# Altium schematic sheet gps.SchDoc
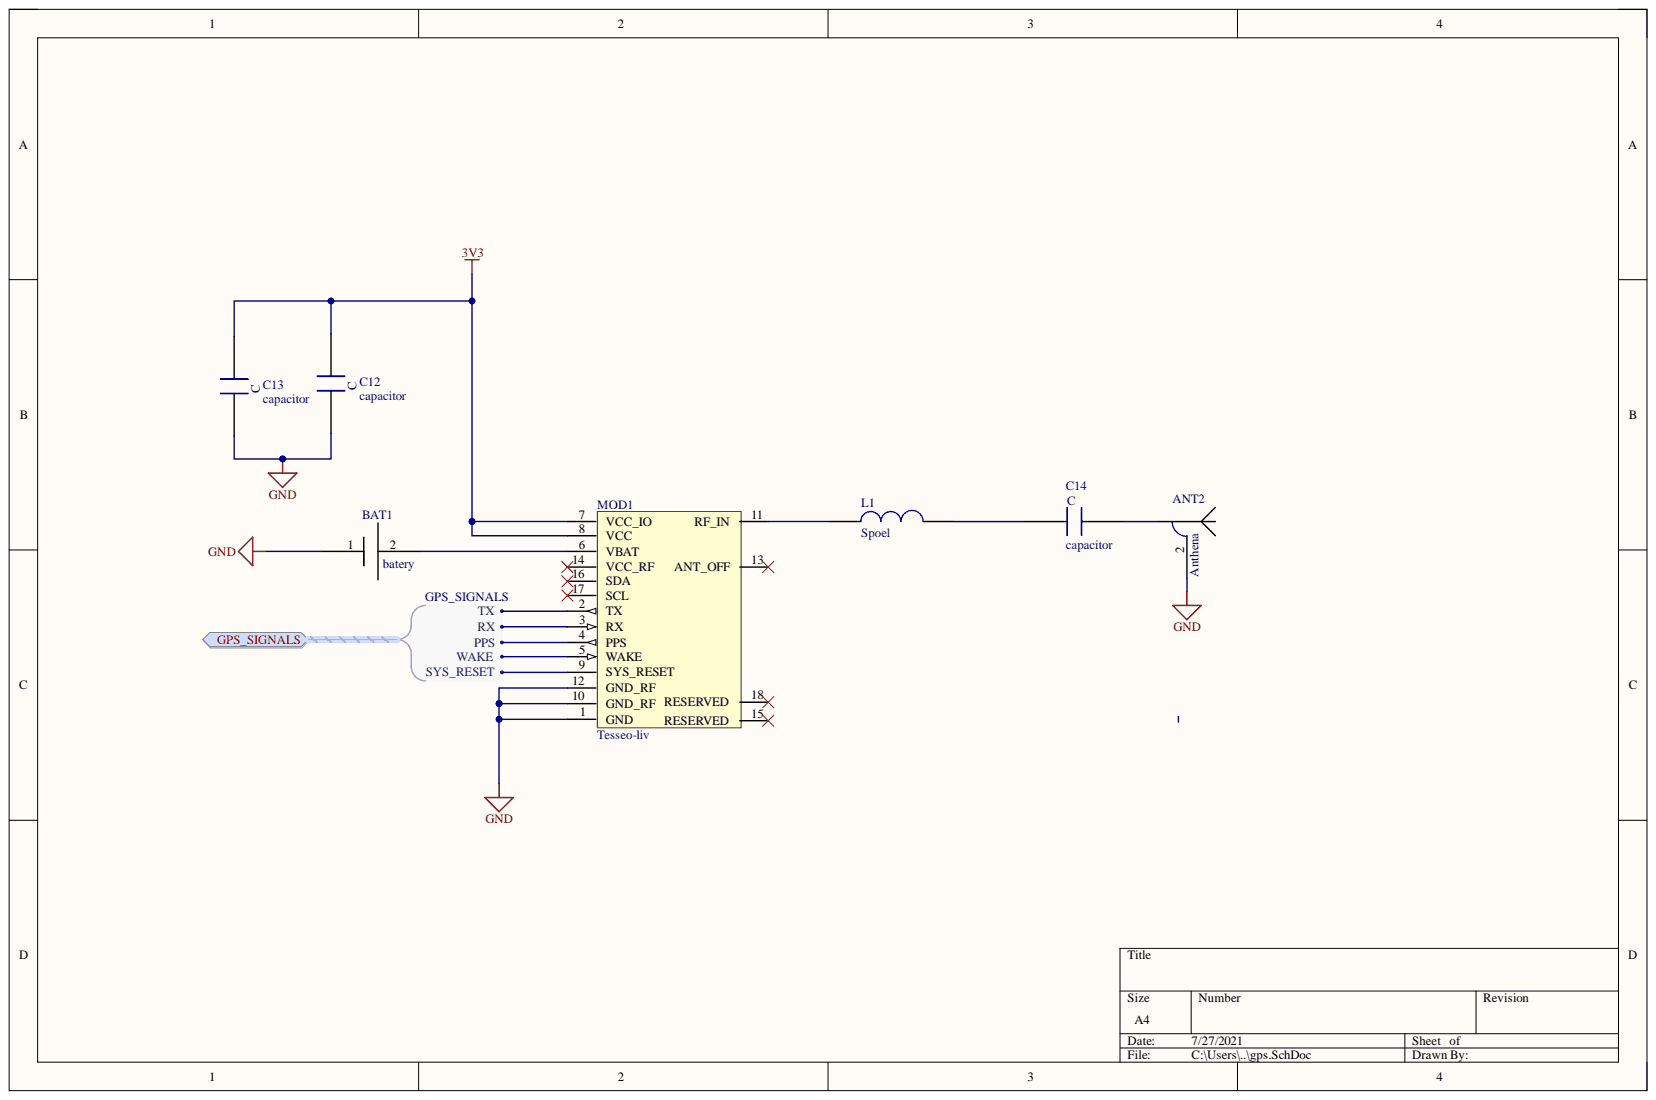
[diagram p0: gps.SchDoc]

## Parts
ANT2  Anthena
BAT1  batery
C12  capacitor  [CAPC1005X04L]
C13  capacitor  [CAPC1005X04L]
C14  capacitor  [CAPC1005X04L]
L1  Spoel  [Coilcraft , XFL4020-102]
MOD1  Tesseo-liv

## Ports
GPS_SIGNALS, GPS_SIGNALS.PPS, GPS_SIGNALS.RX, GPS_SIGNALS.SYS_RESET, GPS_SIGNALS.TX, GPS_SIGNALS.WAKE

## Nets
3V3: C12.1 C13.1 MOD1.7(VCC_IO) MOD1.8(VCC)
GND: ANT2.2 BAT1.1 C12.2 C13.2 MOD1.1(GND) MOD1.10(GND_RF) MOD1.12(GND_RF)
NetANT2_1: ANT2.1 C14.1
NetBAT1_2: BAT1.2 MOD1.6(VBAT)
NetC14_2: C14.2 L1.1
NetL1_2: L1.2 MOD1.11(RF_IN)
NetMOD1_2: MOD1.2(TX) ; port GPS_SIGNALS
NetMOD1_3: MOD1.3(RX) ; port GPS_SIGNALS
NetMOD1_4: MOD1.4(PPS) ; port GPS_SIGNALS
NetMOD1_5: MOD1.5(WAKE) ; port GPS_SIGNALS
NetMOD1_9: MOD1.9(SYS_RESET) ; port GPS_SIGNALS
NetMOD1_13: MOD1.13(ANT_OFF)
NetMOD1_14: MOD1.14(VCC_RF)
NetMOD1_15: MOD1.15(RESERVED)
NetMOD1_16: MOD1.16(SDA)
NetMOD1_17: MOD1.17(SCL)
NetMOD1_18: MOD1.18(RESERVED)

## Library data of the parts
ANT2 = Anthena: Component Kind: Standard; Library Name: pasives.SchLib
BAT1 = batery: Component Kind: Standard; Library Name: conector's_and_TP.SchLib
C12-C14 = capacitor: Component Kind: Standard; Library Name: pasives.SchLib
L1 = Spoel: Component Kind: Standard; Library Name: pasives.SchLib
MOD1 = Tesseo-liv: Component Kind: Standard; Library Name: halfgelijders.SchLib
